# Altium schematic sheet AMS_LV_6.SchDoc (continued)

## Library data of the parts
B1 = Button 2 Conn: Button 2 Conn SMD; Manufacturer: KLS electronic co ltd; Part Number: L-KLS7-TS3608-5.0-180; Component Kind: Standard; ComponentLink1Description: Chip&Dip; ComponentLink1URL: https://www.chipdip.ru/product/kls7-ts3608-5.0-180-td-02xa; Type: Button; Library Name: BRT_Components.SchLib
C1-C6 = Cap 10nF (0603): X7R 10nF 100V 10% 0603; Manufacturer: Murata Manufacturing; Part Number: GRM188R72A103KA01D; Type: Capacitor; Component Kind: Standard; ComponentLink1Description: Chip&Dip; ComponentLink1URL: https://www.chipdip.ru/product/grm188r72a103ka01d; Library Name: BRT_Components.SchLib
C7 C8 C13 C14 = Cap 1uF (0603): 1uF X5R 25V 10% 0603; Manufacturer: Murata Manufacturing; Part Number: GRM188R61E105KAADD; Type: Capacitor; Component Kind: Standard; ComponentLink1Description: Chip&Dip; ComponentLink1URL: https://www.chipdip.ru/product/grm188r61e105k; Library Name: BRT_Components.SchLib
C9 = Cap 4.7uF (0603): 4.7uF X5R 25V 10% 0603; Manufacturer: Murata Manufacturing; Part Number: GRM188R61E475KE11D; Type: Capacitor; Component Kind: Standard; ComponentLink1Description: Chip&Dip; ComponentLink1URL: https://www.chipdip.ru/product0/9000348448; Library Name: BRT_Components.SchLib
C10 C11 = Cap 0.1µF (1206): CERAMIC CAPACITOR 0.1uF, 100V, 10%, 1206; Component Kind: Standard; ComponentLink1Description: ChipDip; ComponentLink1URL: https://www.chipdip.ru/product/ld061c104kab2a; ComponentLink2Description: DataSheet; ComponentLink2URL: http://www.farnell.com/datasheets/2097579.pdf; Library Name: AMS_LV.SchLib; Type: Capacitor
C12 = Cap 1µF (1206): 1uF 100V 10%; Type: Capacitor; Component Kind: Standard; ComponentLink1Description: Chip Dip; ComponentLink1URL: https://www.chipdip.ru/product/12061c105k4z2a-smd-mlcc-1206-100v-x7r-1u-1; ComponentLink2Description: Datasheet; ComponentLink2URL: http://www.farnell.com/datasheets/2237835.pdf; Library Name: AMS_LV.SchLib
C15 C16 = Cap 10pF (0603): 10pF NP0 50V 5% 0603; Manufacturer: Murata Manufacturing; Part Number: GRM1885C1H100JA01D; Type: Capacitor; Component Kind: Standard; ComponentLink1Description: Chip&Dip; ComponentLink1URL: https://www.chipdip.ru/product/grm1885c1h100j; Library Name: BRT_Components.SchLib
C17-C25 = Cap 0.1uF (0603): 0.1uF X7R 16V 10% 0603; Manufacturer: Murata Manufacturing; Part Number: GRM188R71C104KA01D; Type: Capacitor; Component Kind: Standard; ComponentLink1Description: Chip&Dip; ComponentLink1URL: https://www.chipdip.ru/product/grm188r71c104ka01d; Library Name: BRT_Components.SchLib
C26 C28 = Cap Pol 4.7uF: ECAP SMD,  35V, 105°C; Manufacturer: Epcos; Part Number: B41121A7475M000; Type: Capacitor; Component Kind: Standard; ComponentLink1Description: Chip&Dip; ComponentLink1URL: https://www.chipdip.ru/product/b41121a7475m000; Library Name: BRT_Components.SchLib
C27 = Cap Pol 330uF: ECAP SMD,  16V, 105°C, 20%; Manufacturer: JB; Part Number: JCK1C331M080105; Type: Capacitor; Component Kind: Standard; ComponentLink1Description: Chip&Dip; ComponentLink1URL: https://www.chipdip.ru/product0/9000565746; Library Name: BRT_Components.SchLib
D1-D6 = Led Red: SMD 1608, 112mcd, 120°, 630nM (Ultra Bright Red); Manufacturer: Taiwan Oasis Technology; Part Number: TO-1608BC-MRE; Type: Diode; Component Kind: Standard; ComponentLink1Description: Chip&Dip; ComponentLink1URL: https://www.chipdip.ru/product/to-1608bc-mre; Library Name: BRT_Components.SchLib
D7 = TVS Diode 3.3V [SM2T]: High peak pulse power: 200 W (10/1000 μs); Manufacturer: STMicroelectronics; Part Number: SM2T3V3A; Type: Diode; Component Kind: Standard; ComponentLink1Description: DataSheet; ComponentLink1URL: https://www.st.com/resource/en/datasheet/sm2t3v3a.pdf; ComponentLink2Description: Chip&Dip; ComponentLink2URL: https://www.chipdip.ru/product0/8283670575; Library Name: BRT_Components.SchLib
D8 = Diode Schottky (SMB): Diode Schottky 5A 40V; Manufacturer: Diotec Semiconductor; Part Number: SK54; Component Kind: Standard; ComponentLink1Description: DataSheet; ComponentLink1URL: https://static.chipdip.ru/lib/092/DOC001092363.pdf; ComponentLink2Description: Chip&Dip; ComponentLink2URL: https://www.chipdip.ru/product/sk54; Type: Diode; Library Name: BRT_Components.SchLib
D9 = TVS Diode Arrays: Manufacturer: Littelfuse; Part Number: SM24CANB-02HTG; Type: Diode; Component Kind: Standard; ComponentLink1Description: DataSheet; ComponentLink1URL: https://m.littelfuse.com/~/media/electronics/datasheets/tvs_diode_arrays/littelfuse_tvs_diode_array_sm24canb_datasheet.pdf.pdf; ComponentLink2Description: Chip&Dip; ComponentLink2URL: https://www.chipdip.ru/product1/8001779022; Library Name: BRT_Components.SchLib
D10 = Diode Schottky (SOD-123): 0.5 A, Low Forward Voltage less than 385 mV, 400 mW Power Dissipation Package; Manufacturer: ON Semiconductor; Part Number: MBR0520L; Component Kind: Standard; ComponentLink1Description: DataSheet; ComponentLink1URL: http://www.farnell.com/datasheets/1875336.pdf; ComponentLink2Description: Chip&Dip; ComponentLink2URL: https://www.chipdip.ru/product/mbr0520l; Type: Diode; Library Name: BRT_Components.SchLib
D11 = Led Green: Led Green SMD 1608, 120°, 525nM; Manufacturer: Taiwan Oasis Technology; Part Number: TO-1608BC-PG; Type: Diode; Component Kind: Standard; ComponentLink1Description: Chip&Dip; ComponentLink1URL: https://www.chipdip.ru/product/to-1608bc-pg; Library Name: BRT_Components.SchLib
D12 D13 = Diode Schottky (SMA): Diode Schottky 3A 40V; Manufacturer: Vishay; Part Number: B340A-E3/61T; Component Kind: Standard; ComponentLink1Description: DataSheet; ComponentLink1URL: https://static.chipdip.ru/lib/652/DOC001652710.pdf; ComponentLink2Description: Chip&Dip; ComponentLink2URL: https://www.chipdip.ru/product/b340a-e3-61t-2; Type: Diode; Library Name: BRT_Components.SchLib
D14 = Diode Schottky [TO-220-3]: Diode Schottky 2 x 20A 45V; Component Kind: Standard; ComponentLink1Description: ChipDip; ComponentLink1URL: https://www.chipdip.ru/product/vs-40ctq045-m3-vishay; ComponentLink2Description: DataSheet; ComponentLink2URL: https://static.chipdip.ru/lib/208/DOC005208502.pdf; Library Name: AMS_LV.SchLib
J1 J3 = Conn PLS-2: PLS-2 (DS1021-1x2); Component Kind: Standard; ComponentLink1Description: ChipDip; ComponentLink1URL: https://www.chipdip.ru/product/pls-2?yclid=7505697924270808350&utm_source=direct&utm_medium=cpc&position_type=premium%7Ck50id%7C010000001264065_Все%7Ccid%7C50598766%7Cgid%7C4147825070%7Caid%7C8822723075%7Csrc%7Csearch_none&utm_campaign=Y_dinamicheskaya&utm_content=text4_ya&utm_term=; ComponentLink2Description: Datasheet; ComponentLink2URL: https://static.chipdip.ru/lib/977/DOC005977245.pdf; Library Name: AMS_LV.SchLib; Type: Connector
J2 = Connector XT30PW-Male: Manufacturer: Amass; Part Number: XT30PW; Component Kind: Standard; ComponentLink1Description: AliExpress; ComponentLink1URL: https://ru.aliexpress.com/item/4000070113352.html?spm=a2g0o.productlist.0.0.39dd34bfyYuspa&algo_pvid=4d7d83f5-6270-4220-b100-9b5f13b0846d&algo_expid=4d7d83f5-6270-4220-b100-9b5f13b0846d-3&btsid=c67bc929-e3be-4af3-9fd9-25ac13ce4818&ws_ab_test=searchweb0_0,searchweb201602_,searchweb201603_55; Type: Connector; Library Name: BRT_Components.SchLib
J4 = Conn 5 (PLS): Header, 5-Pin; Component Kind: Standard; LatestRevisionDate: 17-Jul-2002; LatestRevisionNote: Re-released for DXP Platform.; Library Name: BRT_Components.SchLib; Publisher: Altium Limited
J5 = Conn4x2 [MX150L]: 5.84mm Pitch MX150L Right-Angle PCB Header, Dual Row, without PCB Flange, 8 Circuits; Manufacturer: Molex; Part Number: 194270017; Component Kind: Standard; ComponentLink1Description: Molex; ComponentLink1URL: https://www.molex.com/molex/products/part-detail/pcb_headers/0194270017; Library Name: AMS_LV.SchLib; Type: Connector
J6 J9 = Conn4x2 [Microfit]: PCB Mount Header, Right Angle,  Wire-to-Board; Manufacturer: Molex; Part Number: 430450801; Component Kind: Standard; ComponentLink1Description: Molex; ComponentLink1URL: https://www.molex.com/molex/products/part-detail/pcb_headers/0430450801; Type: Connector; Library Name: missing_components.schlib
J7 = Conn 2 [MicroFit]: Micro-Fit 3.0 Right-Angle Header, 3.00mm Pitch, Single Row, 2 Circuits, with Snap-in Plastic Peg PCB Lock, Tin, Glow-Wire Capable, Black; Manufacturer: Molex; Part Number: 436500200; Component Kind: Standard; ComponentLink1Description: Molex; ComponentLink1URL: https://www.molex.com/molex/products/part-detail/pcb_headers/0436500200; Type: Connector; Library Name: BRT_Components.SchLib
J8 = Conn6x2 [Microfit]: PCB Mount Header, Right Angle,  Wire-to-Board; Manufacturer: Molex; Part Number: 430451200; Component Kind: Standard; ComponentLink1Description: Molex; ComponentLink1URL: https://www.molex.com/molex/products/part-detail/pcb_headers/0430451200; Type: Connector; Library Name: missing_components.schlib
J10-J13 = Conn12: PCB terminal block, bushing, M5; Manufacturer_Name: Wurth Elektronik*; Component Kind: Standard; ComponentLink1Description: ChipDip; ComponentLink1URL: https://www.chipdip.ru/product/7460408-1; ComponentLink2Description: Datasheet; ComponentLink2URL: http://www.farnell.com/datasheets/1736664.pdf; Datasheet link: http://katalog.we-online.com/em/datasheet/7460408.pdf; Height: 6mm; Library Name: AMS_LV.SchLib; Manufacturer_Part_Number: 7460408; Mouser Part Number: 710-7460408; Mouser Price/Stock: https://www.mouser.co.uk/ProductDetail/Wurth-Elektronik/7460408?qs=lykWx4dhCCG7IAhyxQ5B4w%3D%3D; Type: Terminal block
Q1-Q3 = NPN [PBS]: NPN low VCEsat transistor in a SOT223 plastic package; Manufacturer: Nexperia; Part Number: PBSS4540Z,115; Component Kind: Standard; ComponentLink1Description: DataSheet; ComponentLink1URL: https://static.chipdip.ru/lib/583/DOC001583057.pdf; ComponentLink2Description: Chip&Dip; ComponentLink2URL: https://www.chipdip.ru/product/pbss4540z.115; Type: Transistor; Library Name: BRT_Components.SchLib
R1-R3 R11 R12 R20 R22 = Res 75R (0805): 0.125W 0805 75R, 1%; Manufacturer: Taiwan; Part Number: RC0805FR-0775RL; Component Kind: Standard; ComponentLink1Description: Chip&Dip; ComponentLink1URL: https://www.chipdip.ru/product0/9000079459; Type: Resistor; Library Name: BRT_Components.SchLib
R4-R10 = Res 39R (2512): 2512 47R 1%; Component Kind: Standard; ComponentLink1Description: ChipDip; ComponentLink1URL: https://www.chipdip.ru/product0/9000190077?from=suggest_product; ComponentLink2Description: Datasheet; ComponentLink2URL: https://static.chipdip.ru/lib/788/DOC004788200.pdf; Library Name: AMS_LV.SchLib; Type: Resistor
R13-R19 = Res 100R (603): 603 100R 1% 0.1W; Component Kind: Standard; ComponentLink1Description: ChipDip; ComponentLink1URL: https://www.chipdip.ru/product0/9000079616; ComponentLink2Description: Datasheet; ComponentLink2URL: https://static.chipdip.ru/lib/788/DOC004788200.pdf; Library Name: AMS_LV.SchLib; Type: Resistor
R21 = Res 62R (0603): 0.1W 0603 62R, 1%; Manufacturer: Taiwan; Part Number: RC0603FR-0762RL; Component Kind: Standard; ComponentLink1Description: Chip&Dip; ComponentLink1URL: https://www.chipdip.ru/product0/9000079611; Type: Resistor; Library Name: BRT_Components.SchLib
R23 = Res 10R (603): 603 10R 75V; Component Kind: Standard; ComponentLink1Description: ChipDip; ComponentLink1URL: https://www.chipdip.ru/product/crcw060310r0fkea; ComponentLink2Description: Datasheet; ComponentLink2URL: http://www.farnell.com/datasheets/2310790.pdf; Library Name: AMS_LV.SchLib; Type: Resistor
R24 = Res 1M (0805): 0.125W 0805 1 MR, 5%; Manufacturer: Taiwan; Part Number: RC0805JR-071ML; Component Kind: Standard; ComponentLink2Description: Chip&Dip; ComponentLink2URL: https://www.chipdip.ru/product0/830469679; Type: Resistor; Library Name: BRT_Components.SchLib
R25 = Res 100R (1206): 1206 100R 1%; Component Kind: Standard; ComponentLink1Description: Chip Dip; ComponentLink1URL: https://www.chipdip.ru/product/cr1206-fx-1000elf-smd-1206-thick-film-resis; ComponentLink2Description: Datasheet; ComponentLink2URL: http://www.farnell.com/datasheets/2178762.pdf; Library Name: AMS_LV.SchLib; Type: Resistor
R26 = Res 0R (0603): 0.1W 0603 0R, 5%; Manufacturer: Taiwan; Part Number: RC0603JR-070RL; Component Kind: Standard; ComponentLink1Description: Chip&Dip; ComponentLink1URL: https://www.chipdip.ru/product0/9000070541; Type: Resistor; Library Name: BRT_Components.SchLib
R27 R41 = Res 300R (603): 0603 300R 1%; Component Kind: Standard; ComponentLink1Description: ChipDip; ComponentLink1URL: https://www.chipdip.ru/product0/9000079627; ComponentLink2Description: DataSheet; ComponentLink2URL: https://static.chipdip.ru/lib/788/DOC004788200.pdf; Library Name: AMS_LV.SchLib; Type: Resistor
R28-R32 R36-R38 R40 = Res 10k (0603): 0.1W 0603 10k, 1%; Manufacturer: Taiwan; Part Number: RC0603FR-0710KL; Component Kind: Standard; ComponentLink1Description: Chip&Dip; ComponentLink1URL: https://www.chipdip.ru/product0/9000079736; Type: Resistor; Library Name: BRT_Components.SchLib
R33 R34 = Res 1k (0603): 0.1W 0603 1k, 1%; Manufacturer: Taiwan; Part Number: RC0603FR-071KL; Component Kind: Standard; ComponentLink1Description: Chip&Dip; ComponentLink1URL: https://www.chipdip.ru/product0/9000079676; Type: Resistor; Library Name: BRT_Components.SchLib
R35 = Res 5.1k (603): 0.1W 0603 5.1 kOhm, 1%; Component Kind: Standard; ComponentLink1Description: ChipDip; ComponentLink1URL: https://www.chipdip.ru/product0/9000079729; ComponentLink2Description: Datasheet; ComponentLink2URL: https://static.chipdip.ru/lib/788/DOC004788200.pdf; Library Name: AMS_LV.SchLib; Type: Resistor
R39 = Res 3.9k (0603): 0.1W 0603 3.9k, 1%; Manufacturer: Taiwan; Part Number: RC0603FR-073K9L; Component Kind: Standard; ComponentLink1Description: Chip&Dip; ComponentLink1URL: https://www.chipdip.ru/product0/9000079726; Type: Resistor; Library Name: BRT_Components.SchLib
RL1 = Relay 24V 6A: Coil voltage: 24V; 6A max; Manufacturer: TE Connectivity; Part Number: RE030024; Component Kind: Standard; ComponentLink1Description: Chip&Dip; ComponentLink1URL: https://www.chipdip.ru/product/tyco-re030024-1393217-8; ComponentLink2Description: Datasheet; ComponentLink2URL: https://static.chipdip.ru/lib/975/DOC000975764.pdf; Type: Relay; Library Name: BRT_Components.SchLib
T1 = ACT45B: Common mode filters  Automotive signal line (for power train/safety); Manufacturer: EMC Components; Part Number: ACT45B-510-2P-TL003; Type: Inductor; Component Kind: Standard; ComponentLink1Description: DataSheet; ComponentLink1URL: https://product.tdk.com/info/en/catalog/datasheets/cmf_automotive_signal_act45b_en.pdf; Library Name: BRT_Components.SchLib
U1 = LDO 3.3V [MCP]: A family of CMOS low dropout (LDO)voltage  regulators  that  can  deliver  up  to  250 mA  ofcurrent  while  consuming  only  1.6 μA  of  quiescentcurrent (typical). The input operating range is specifiedfrom 2.3V to 6.0V; Manufacturer: Microchip; Part Number: MCP1700T-3302E/TT; Component Kind: Standard; ComponentLink1Description: DataSheet; ComponentLink1URL: https://static.chipdip.ru/lib/276/DOC000276804.pdf; ComponentLink2Description: Chip&Dip; ComponentLink2URL: https://www.chipdip.ru/product/mcp1700t-3302e-tt; ComponentLink3Description: Elitan; ComponentLink3URL: https://www.elitan.ru/price/item595361; Library Name: BRT_Components.SchLib
U2 = CAN Transceiver [MCP2551]: High-speed  CAN,  fault-tolerantdevice  that  serves  as  the  interface  between  a  CANprotocol controller and the physical bus.; Manufacturer: Microchip; Part Number: MCP2551-I/SN; Component Kind: Standard; ComponentLink1Description: DataSheet; ComponentLink1URL: https://static.chipdip.ru/lib/204/DOC000204457.pdf; ComponentLink2Description: Chip&Dip; ComponentLink2URL: https://www.chipdip.ru/product/mcp2551-i-sn; Type: IC; Library Name: BRT_Components.SchLib
U3 = STM32F103C8T6: Cortex™-M3 32-bit RISC core operating at a 72 MHz frequency; Manufacturer: STMicroelectronics; Component Kind: Standard; ComponentLink1Description: DataSheet; ComponentLink1URL: https://static.chipdip.ru/lib/235/DOC000235733.pdf; ComponentLink2Description: Chip&Dip; ComponentLink2URL: https://www.chipdip.ru/product/stm32f103c8t6; Part Number: STM32F103C8T6; Type: IC; Library Name: BRT_Components.SchLib
U4 = Optocupler [LTV-817S]: LTV-817S, 5000 Vrms, SMD-4, 4-pin, -30...+110 degC; Component Kind: Standard; ComponentLink1Description: ChipDip; ComponentLink1URL: https://www.chipdip.ru/product0/8001777801; ComponentLink2Description: Datasheet; ComponentLink2URL: https://static6.arrow.com/aropdfconversion/acb51fbce558b47de527fd2f65fc66930fdd9300/2s_110_ltv-817202082720208472020m20s20s-ta20s-t.pdf; Library Name: AMS_LV.SchLib
VD1 = TVS Diode 26V [SMF26A]: SMF26A 200W; Type: Diode; Component Kind: Standard; ComponentLink1Description: ChipDip; ComponentLink1URL: https://www.chipdip.ru/product/smf26a; ComponentLink2Description: Datasheet; ComponentLink2URL: https://static.chipdip.ru/lib/152/DOC001152952.pdf; Library Name: AMS_LV.SchLib
Y1 = Crystal 8MHz SMD: Crystal. HC-49SM (US); Manufacturer: China; Part Number: 8.000MHzHC-49SM; Component Kind: Standard; ComponentLink1Description: Chip&Dip; ComponentLink1URL: https://www.chipdip.ru/product/8mhz-hc-49sm; Type: Crystal; Library Name: BRT_Components.SchLib
DD1 = LTC6810: Component Kind: Standard; ComponentLink1Description: ChipDip; ComponentLink1URL: https://www.chipdip.ru/product1/8004965185; ComponentLink2Description: Datasheet; ComponentLink2URL: https://www.analog.com/ru/products/ltc6810-1.html#product-overview; Library Name: LTC6810.SchLib
SB1 = Circuit Breaker: Component Kind: Standard; Description: Circuit Breaker; Library Name: BRT_Components.SchLib
